# Altium schematic sheet Sheet1.SchDoc
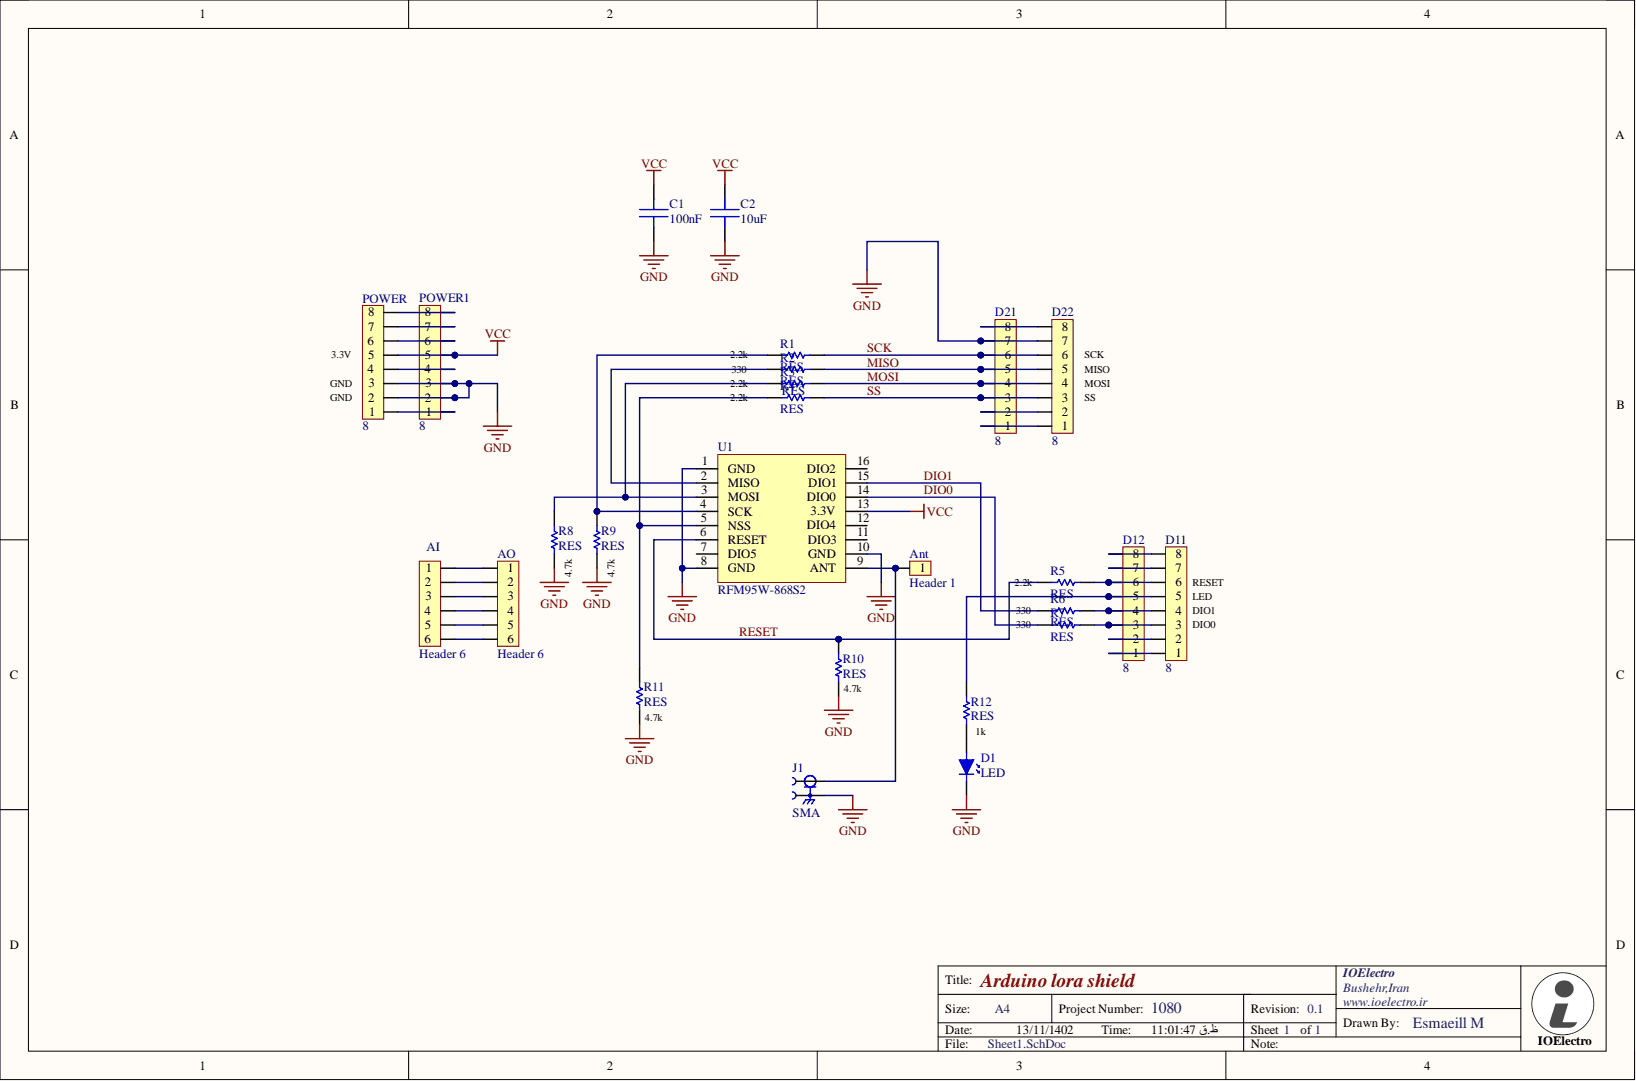
[diagram p0: Sheet1.SchDoc]

## Parts
AI  Header 6  [PH-1X6]
Ant  Header 1  [PH-1X1]
AO  Header 6  [PH-1X6]
C1  100nF  [C-0805]
C2  10uF  [C-0805]
D1  LED  [LED-0805]
D11  8  [PH-1X8]
D12  8  [PH-1X8]
D21  8  [PH-1X8]
D22  8  [PH-1X8]
J1  SMA  [SMA-CONNECTOR-R]
POWER  8  [PH-1X8]
POWER1  8  [PH-1X8]
R1  RES  [R-0805]
R2  RES  [R-0805]
R3  RES  [R-0805]
R4  RES  [R-0805]
R5  RES  [R-0805]
R6  RES  [R-0805]
R7  RES  [R-0805]
R8  RES  [R-0805]
R9  RES  [R-0805]
R10  RES  [R-0805]
R11  RES  [R-0805]
R12  RES  [R-0805]
U1  RFM95W-868S2  [RFM95]

## Nets
GND: C1.2 C2.2 D1.K D21.7 D22.7 J1.2 POWER.2 POWER.3 POWER1.2 POWER1.3 R8.1 R9.1 R10.1 R11.1 U1.1(GND) U1.8(GND) U1.10(GND)
VCC: C1.1 C2.1 POWER.5 POWER1.5 U1.13(3.3V)
DIO0: R7.1 U1.14(DIO0)
DIO1: R6.1 U1.15(DIO1)
MISO: D21.5 D22.5 R2.2
MOSI: D21.4 D22.4 R3.2
RESET: R5.1 R10.2 U1.6(RESET)
SCK: D21.6 D22.6 R1.2
SS: D21.3 D22.3 R4.2
NetAI_1: AI.1 AO.1
NetAI_2: AI.2 AO.2
NetAI_3: AI.3 AO.3
NetAI_4: AI.4 AO.4
NetAI_5: AI.5 AO.5
NetAI_6: AI.6 AO.6
NetAnt_1: Ant.1 J1.1 U1.9(ANT)
NetD1_A: D1.A R12.1
NetD11_1: D11.1 D12.1
NetD11_2: D11.2 D12.2
NetD11_3: D11.3 D12.3 R7.2
NetD11_4: D11.4 D12.4 R6.2
NetD11_5: D11.5 D12.5 R12.2
NetD11_6: D11.6 D12.6 R5.2
NetD11_7: D11.7 D12.7
NetD11_8: D11.8 D12.8
NetD21_1: D21.1 D22.1
NetD21_2: D21.2 D22.2
NetD21_8: D21.8 D22.8
NetPOWER1_1: POWER.1 POWER1.1
NetPOWER1_4: POWER.4 POWER1.4
NetPOWER1_6: POWER.6 POWER1.6
NetPOWER1_7: POWER.7 POWER1.7
NetPOWER1_8: POWER.8 POWER1.8
NetR1_1: R1.1 R9.2 U1.4(SCK)
NetR2_1: R2.1 U1.2(MISO)
NetR3_1: R3.1 R8.2 U1.3(MOSI)
NetR4_1: R4.1 R11.2 U1.5(NSS)

Not connected: U1 7(DIO5) 11(DIO3) 12(DIO4) 16(DIO2)

## Library data of the parts
AI AO = PH-1X6: PIN HEADER 1x6 2.56mm; Component Kind: Standard; Library Name: IOElectro.IntLib
Ant = PH-1X1: PIN HEADER 1x1 2.56mm; Component Kind: Standard; Library Name: IOElectro.IntLib
C1 C2 = CAP: CAPACITOR BIPOLAR; Component Kind: Standard; Library Name: IOElectro.IntLib
D11 D12 D21 D22 POWER POWER1 = PH-1X8: PIN HEADER 1x8 2.56mm; Component Kind: Standard; Library Name: IOElectro.IntLib
J1 = SMA-CONNECTOR: Straight SMA Connector; MP: altium_native_batch; Availability: Unavailable; Component Kind: Standard; Library Name: IOElectro.IntLib; Package: None; Price: None
U1 = RFM95W-868S2: Transceiver RF 868 MHz (+20/-139dBm), FSK, GFSK, MSK, GMSK, LoRaTM, OOK, 16 x 16 mm; Manufacturer: RF Solutions; Current - Receiving: 12.1mA; Current - Transmitting: 120mA; Data Rate: 300Kbps; Frequency: 868MHz; Interface: SPI; Sensitivity: -123dBm; Case/Package: SMD/SMT; Category: RF Receivers, Transceivers; Component Kind: Standard; ComponentLink1Description: https://www.rfsolutions.co.uk/downloads/1463993415RFM95_96_97_98W.pdf?src-supplier=Digikey; ComponentLink1URL: https://www.rfsolutions.co.uk/downloads/1463993415RFM95_96_97_98W.pdf?src-supplier=Digikey; Library Name: IOElectro.IntLib; Manufacturer Part Number: RFM95W-868S2; Max Operating Temperature: 70°C; Min Operating Temperature: -20°C; Mount: Surface Mount; Packaging: Bulk; Pricing 1: 1=22/49, 10=20/77, 50=18/46, 100=17/59, 1000=15/57, 10000=15/57 (USD); RoHS: Yes; Stock 1: 200; Supplier 1: Mouser; Supplier Part Number 1: 223-RFM95W-868S2
D1 = LED: Component Kind: Standard; Description: LED; Library Name: IOElectro.IntLib
R1-R12 = RES: Component Kind: Standard; Library Name: IOElectro.IntLib
